# Altium schematic sheet schematic.SchDoc(schematic)
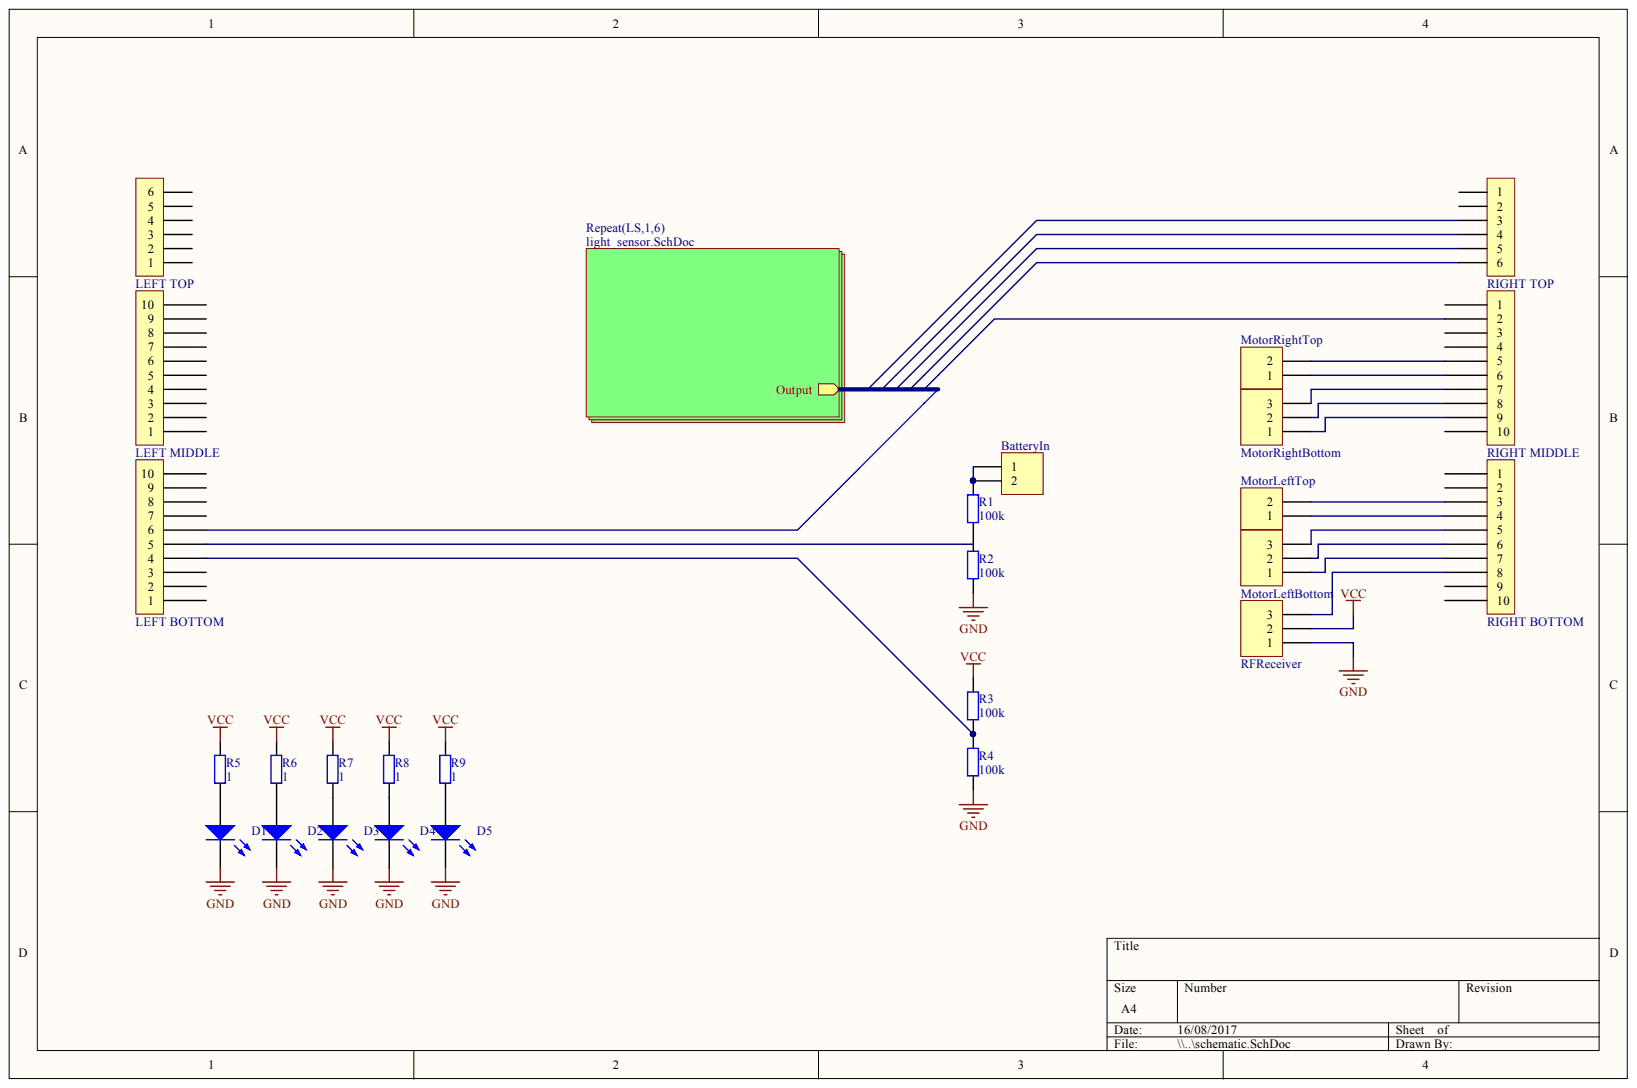
[diagram p0: schematic.SchDoc]

## Parts
BatteryIn  2 Way  [ECE-600102STTH]  (value not drawn)
D1  LTST-C170KAKT  [ECE-LED2012-0805SM]  (value not drawn)
D2  LTST-C170KAKT  [ECE-LED2012-0805SM]  (value not drawn)
D3  LTST-C170KAKT  [ECE-LED2012-0805SM]  (value not drawn)
D4  LTST-C170KAKT  [ECE-LED2012-0805SM]  (value not drawn)
D5  LTST-C170KAKT  [ECE-LED2012-0805SM]  (value not drawn)
LEFT_BOTTOM  10 Way  [ECE-600110STTH]  (value not drawn)
LEFT_MIDDLE  10 Way  [ECE-600110STTH]  (value not drawn)
LEFT_TOP  6 Way  [ECE-600106STTH]  (value not drawn)
MotorLeftBottom  3 Way  [ECE-600103STTH]  (value not drawn)
MotorLeftTop  2 Way  [ECE-600102STTH]  (value not drawn)
MotorRightBottom  3 Way  [ECE-600103STTH]  (value not drawn)
MotorRightTop  2 Way  [ECE-600102STTH]  (value not drawn)
R1  100k  [ECE-RESA0.4TH]
R2  100k  [ECE-RESA0.4TH]
R3  100k  [ECE-RESA0.4TH]
R4  100k  [ECE-RESA0.4TH]
R5  1  [ECE-RESA0.4TH]
R6  1  [ECE-RESA0.4TH]
R7  1  [ECE-RESA0.4TH]
R8  1  [ECE-RESA0.4TH]
R9  1  [ECE-RESA0.4TH]
RFReceiver  3 Way  [ECE-600103STTH]  (value not drawn)
RIGHT_BOTTOM  10 Way  [ECE-600110STTH]  (value not drawn)
RIGHT_MIDDLE  10 Way  [ECE-600110STTH]  (value not drawn)
RIGHT_TOP  6 Way  [ECE-600106STTH]  (value not drawn)

## Nets
GND: D1.2 D2.2 D3.2 D4.2 D5.2 R2.1 R4.1 RFReceiver.1
VCC: R3.2 R5.2 R6.2 R7.2 R8.2 R9.2 RFReceiver.2
NetBatteryIn_1: BatteryIn.1 BatteryIn.2 R1.2
NetD1_1: D1.1 R5.1
NetD2_1: D2.1 R6.1
NetD3_1: D3.1 R7.1
NetD4_1: D4.1 R8.1
NetD5_1: D5.1 R9.1
NetLEFT BOTTOM_1: LEFT_BOTTOM.1
NetLEFT BOTTOM_2: LEFT_BOTTOM.2
NetLEFT BOTTOM_3: LEFT_BOTTOM.3
NetLEFT BOTTOM_4: LEFT_BOTTOM.4 R3.1 R4.2
NetLEFT BOTTOM_5: LEFT_BOTTOM.5
NetLEFT BOTTOM_6: LEFT_BOTTOM.6
NetLEFT BOTTOM_7: LEFT_BOTTOM.7
NetLEFT BOTTOM_8: LEFT_BOTTOM.8
NetLEFT BOTTOM_9: LEFT_BOTTOM.9
NetLEFT BOTTOM_10: LEFT_BOTTOM.10
NetLEFT MIDDLE_1: LEFT_MIDDLE.1
NetLEFT MIDDLE_2: LEFT_MIDDLE.2
NetLEFT MIDDLE_3: LEFT_MIDDLE.3
NetLEFT MIDDLE_4: LEFT_MIDDLE.4
NetLEFT MIDDLE_5: LEFT_MIDDLE.5
NetLEFT MIDDLE_6: LEFT_MIDDLE.6
NetLEFT MIDDLE_7: LEFT_MIDDLE.7
NetLEFT MIDDLE_8: LEFT_MIDDLE.8
NetLEFT MIDDLE_9: LEFT_MIDDLE.9
NetLEFT MIDDLE_10: LEFT_MIDDLE.10
NetLEFT TOP_1: LEFT_TOP.1
NetLEFT TOP_2: LEFT_TOP.2
NetLEFT TOP_3: LEFT_TOP.3
NetLEFT TOP_4: LEFT_TOP.4
NetLEFT TOP_5: LEFT_TOP.5
NetLEFT TOP_6: LEFT_TOP.6
NetMotorLeftBottom_1: MotorLeftBottom.1 RIGHT_BOTTOM.7
NetMotorLeftBottom_2: MotorLeftBottom.2 RIGHT_BOTTOM.6
NetMotorLeftBottom_3: MotorLeftBottom.3 RIGHT_BOTTOM.5
NetMotorLeftTop_1: MotorLeftTop.1 RIGHT_BOTTOM.4
NetMotorLeftTop_2: MotorLeftTop.2 RIGHT_BOTTOM.3
NetMotorRightBottom_1: MotorRightBottom.1 RIGHT_MIDDLE.9
NetMotorRightBottom_2: MotorRightBottom.2 RIGHT_MIDDLE.8
NetMotorRightBottom_3: MotorRightBottom.3 RIGHT_MIDDLE.7
NetMotorRightTop_1: MotorRightTop.1 RIGHT_MIDDLE.6
NetMotorRightTop_2: MotorRightTop.2 RIGHT_MIDDLE.5
NetR1_1: R1.1 R2.2
NetRFReceiver_3: RFReceiver.3 RIGHT_BOTTOM.8
NetRIGHT BOTTOM_1: RIGHT_BOTTOM.1
NetRIGHT BOTTOM_2: RIGHT_BOTTOM.2
NetRIGHT BOTTOM_9: RIGHT_BOTTOM.9
NetRIGHT BOTTOM_10: RIGHT_BOTTOM.10
NetRIGHT MIDDLE_1: RIGHT_MIDDLE.1
NetRIGHT MIDDLE_2: RIGHT_MIDDLE.2
NetRIGHT MIDDLE_3: RIGHT_MIDDLE.3
NetRIGHT MIDDLE_4: RIGHT_MIDDLE.4
NetRIGHT MIDDLE_10: RIGHT_MIDDLE.10
NetRIGHT TOP_1: RIGHT_TOP.1
NetRIGHT TOP_2: RIGHT_TOP.2
NetRIGHT TOP_3: RIGHT_TOP.3
NetRIGHT TOP_4: RIGHT_TOP.4
NetRIGHT TOP_5: RIGHT_TOP.5
NetRIGHT TOP_6: RIGHT_TOP.6
N00050:
OUTPUT:

## Library data of the parts
BatteryIn MotorLeftTop MotorRightTop = CON000001: HEADER POLARISED 2WAY TH; Manufactuer: GTK; Manufacturer-PN: 600102ST; Assembly Method: Through hole; Database Table Name: Connectors$; Item Type: Item; Path: ece/ts/Lists/Stock Inventory; Category: Connector; Component Kind: Standard; Component Type: NA; Datasheet Link: http://share.engineering.auckland.ac.nz/ece/ts/Student%20Documents/polarised_connectors.pdf; Library Name: EceParts.DbLib; Size/Package: 2.54 Radial; Status: Active; Supplier1: Elecon; Supplier1-PN: YY3N02; Supplier2: Active Components; Supplier2-PN: 600102ST; UoA Stock#: CON000001; Value: 2 Way
D1-D5 = DIO000105: LED RED ORANGE CLEAR 0805 SMD; Manufactuer: Lite-On Inc; Assembly Method: Surface Mount; Database Table Name: Diodes$; Item Type: Item; Path: ece/ts/Lists/Stock Inventory; Voltage/Current: 20mA; Category: Diode; Component Kind: Standard; Component Type: LED-0805-RED; Datasheet Link: http://share.engineering.auckland.ac.nz/ece/ts/Student%20Documents/LED-S_110_LTST-C170KAKT.pdf; Library Name: EceParts.DbLib; Manufacturer-PN: LTST-C170KAKT; Size/Package: 0805 (2012 Metric); Status: Active; Supplier1: Digikey; Supplier1-PN: 160-1412-6-ND; UoA Stock#: DIO000105; Value: LTST-C170KAKT
LEFT_BOTTOM LEFT_MIDDLE RIGHT_BOTTOM RIGHT_MIDDLE = CON000006: HEADER POLARISED 10WAY TH; Manufactuer: GTK; Manufacturer-PN: 600110ST; Assembly Method: Through hole; Database Table Name: Connectors$; Item Type: Item; Path: ece/ts/Lists/Stock Inventory; Category: Connector; Component Kind: Standard; Component Type: NA; Datasheet Link: http://share.engineering.auckland.ac.nz/ece/ts/Student%20Documents/polarised_connectors.pdf; Library Name: EceParts.DbLib; Size/Package: 2.54 Radial; Status: Active; Supplier1: Elecon; Supplier1-PN: YY3N10; Supplier2: Active Components; Supplier2-PN: 600110ST; UoA Stock#: CON000006; Value: 10 Way
LEFT_TOP RIGHT_TOP = CON000004: HEADER POLARISED 6WAY TH; Manufactuer: GTK; Manufacturer-PN: 600106ST; Assembly Method: Through hole; Database Table Name: Connectors$; Item Type: Item; Path: ece/ts/Lists/Stock Inventory; Category: Connector; Component Kind: Standard; Component Type: NA; Datasheet Link: http://share.engineering.auckland.ac.nz/ece/ts/Student%20Documents/polarised_connectors.pdf; Library Name: EceParts.DbLib; Size/Package: 2.54 Radial; Status: Active; Supplier1: Elecon; Supplier1-PN: YY3N06; Supplier2: Active Components; Supplier2-PN: 600106ST; UoA Stock#: CON000004; Value: 6 Way
MotorLeftBottom MotorRightBottom RFReceiver = CON000002: HEADER POLARISED 3WAY TH; Manufactuer: GTK; Manufacturer-PN: 600103ST; Assembly Method: Through hole; Database Table Name: Connectors$; Item Type: Item; Path: ece/ts/Lists/Stock Inventory; Category: Connector; Component Kind: Standard; Component Type: NA; Datasheet Link: http://share.engineering.auckland.ac.nz/ece/ts/Student%20Documents/polarised_connectors.pdf; Library Name: EceParts.DbLib; Size/Package: 2.54 Radial; Status: Active; Supplier1: Elecon; Supplier1-PN: YY3N03; Supplier2: Active Components; Supplier2-PN: 600103ST; UoA Stock#: CON000002; Value: 3 Way
R1-R4 = RES000001: RES MF 1R TH; Assembly Method: Through hole; Database Table Name: Resistors$; Item Type: Item; Path: ece/ts/Lists/Stock Inventory; Value: 1; Voltage/Current: 0.6W; Category: Resistor; Component Kind: Standard; Component Type: NA; Library Name: EceParts.DbLib; Size/Package: Axial 10mm; Status: Active; Supplier1: Element14; Supplier1-PN: 335216; UoA Stock#: RES000001
R5-R9 = RES000001: RES MF 1R TH; Assembly Method: Through hole; Database Table Name: Resistors$; Item Type: Item; Path: ece/ts/Lists/Stock Inventory; Voltage/Current: 0.6W; Category: Resistor; Component Kind: Standard; Component Type: NA; Library Name: EceParts.DbLib; Size/Package: Axial 10mm; Status: Active; Supplier1: Element14; Supplier1-PN: 335216; UoA Stock#: RES000001; Value: 1
